# Altium schematic sheet Schematic.SchDoc
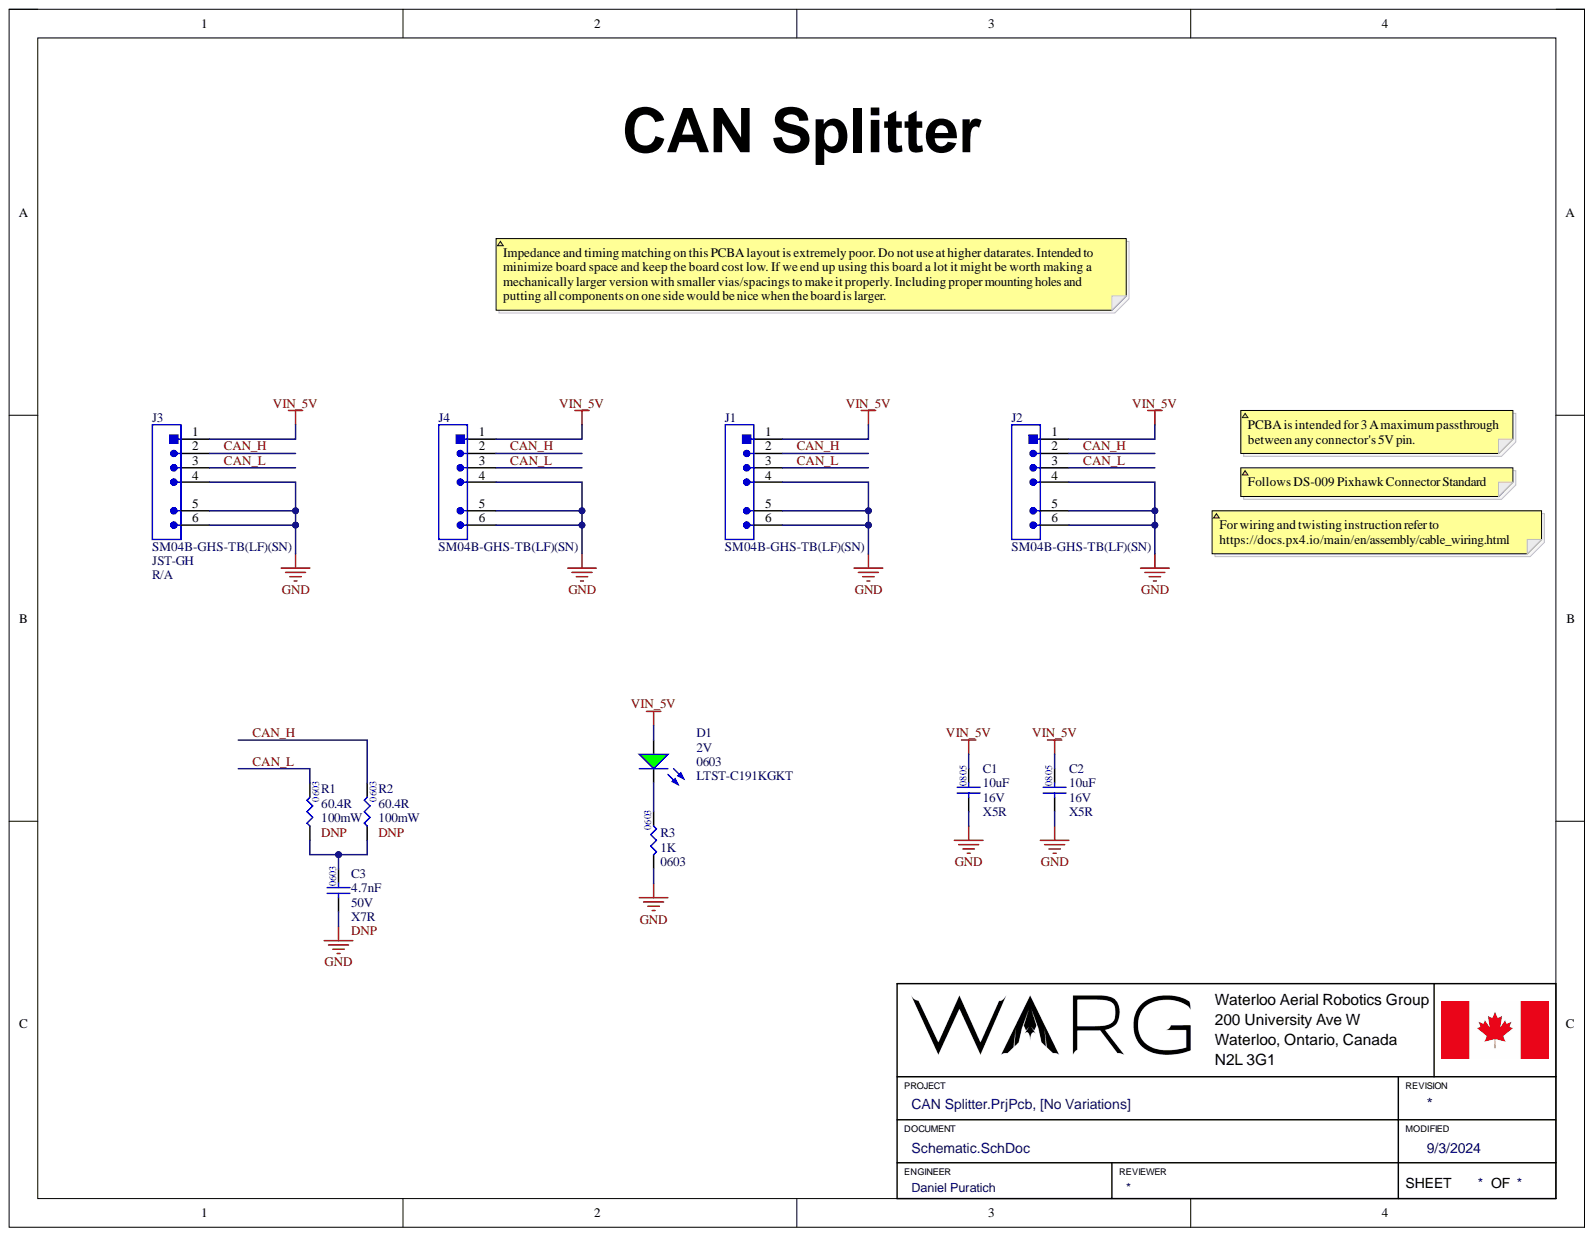
[diagram p0: Schematic.SchDoc]

## Parts
C1  value 10uF  Capacitance: 10uF  Package: 0805  Temperature Coefficient: X5R  Voltage: 16V  [.CAP_0805-Footprint-1]  (hidden comment: CL21A106KOQNNNE)
C2  value 10uF  Capacitance: 10uF  Package: 0805  Temperature Coefficient: X5R  Voltage: 16V  [.CAP_0805-Footprint-1]  (hidden comment: CL21A106KOQNNNE)
C3  value 4.7nF  Case/Package: 0603  Rated DC Voltage (URdc): 50V  Temperature Characteristics Code: X7R  [.CAP_0603-Footprint-1]  (hidden comment: GCM188R71H472KA37D)
D1  LTST-C191KGKT  Case/Package: 0603  Forward Voltage: 2V  [.LED_0603-Footprint-1]
J1  SM04B-GHS-TB(LF)(SN)  [CONN_SM04B-GHS-TB_JST]
J2  SM04B-GHS-TB(LF)(SN)  [CONN_SM04B-GHS-TB_JST]
J3  SM04B-GHS-TB(LF)(SN)  Mfr_Name: JST-GH  [CONN_SM04B-GHS-TB_JST]
J4  SM04B-GHS-TB(LF)(SN)  [CONN_SM04B-GHS-TB_JST]
R1  value 60.4R  Power: 100mW  [RES0603]  (hidden comment: RC0603FR-1360R4L)
R2  value 60.4R  Power: 100mW  [RES0603]  (hidden comment: RC0603FR-1360R4L)
R3  value 1K  Case/Package: 0603  [.RES_0603-Footprint-1]  (hidden comment: RC0603FR-071KL)

## Nets
GND: C1.1 C2.1 C3.1 J1.4 J1.5 J1.6 J2.4 J2.5 J2.6 J3.4 J3.5 J3.6 J4.4 J4.5 J4.6 R3.1
VIN_5V: C1.2 C2.2 D1.1 J1.1 J2.1 J3.1 J4.1
CAN_H: J1.2 J2.2 J3.2 J4.2 R2.2
CAN_L: J1.3 J2.3 J3.3 J4.3 R1.2
NetC3_2: C3.2 R1.1 R2.1
NetD1_2: D1.2 R3.2

## Library data of the parts
C1 C2 = CMP-001-00016-5: CAP CER 10UF 16V X5R 0805; Component Kind: Standard; Library Name: WARG
C3 = CMP-001-00080-1: CAP CER 4700PF 50V X7R 0603; Capacitor Type: Ceramic; Multilayer: Yes; Reference Standard: AEC-Q200; Tolerance  (fill in as +-): 10%; Component Kind: Standard; Dielectric Material: Ceramic; DNP: DNP; Height: 0.9mm; JESD-609 Code: e3; Length: 1.6mm; Max Operating Temperature: 125°C; Min Operating Temperature: -55°C; Mounting Technology: Surface Mount; Package Shape: Rectangular; Package Style: SMTMeter; Packaging: Tape and Reel; Pins: 2; Reach SVHC Compliant: Yes; RoHS Compliant: Yes; Temperature Coefficient: X7R; Terminal Finish: Nickel; Termination: Wraparound; Tolerance (fill in as +-): 10%; Width: 0.8mm; Library Name: WARG
D1 = CMP-001-00018-5: LED GREEN 2V 0603; Forward Current: 20mA; Color: Green; Component Kind: Standard; Library Name: WARG
J1 J2 J4 = CMP-068-000000-2: Conn Shrouded Header HDR 4 POS 1.25mm Solder RA SMD Reel; Mfr_Name: JST; Component Kind: Standard; Design Item ID: SM04B-GHS-TB(LF)(SN); RefDes: RefDes; Source: SM04B-GHS-TB_LF__SN_.SchLib; Type: Type; Library Name: WARG
J3 = CMP-068-000000-2: Conn Shrouded Header HDR 4 POS 1.25mm Solder RA SMD Reel; Component Kind: Standard; Design Item ID: SM04B-GHS-TB(LF)(SN); RefDes: RefDes; Source: SM04B-GHS-TB_LF__SN_.SchLib; Type: R/A; Library Name: WARG
R1 R2 = CMP-00245-1: RES 60.4 OHM 1% 1/10W 0603; Component Kind: Standard; DNP: DNP; Tolerance: 1%; Library Name: WARG
R3 = CMP-009-00012-3: RES 1k OHM 1% 1/10W 0603; Component Kind: Standard; Library Name: WARG
